# Altium schematic sheet [03] Connectors.SchDoc
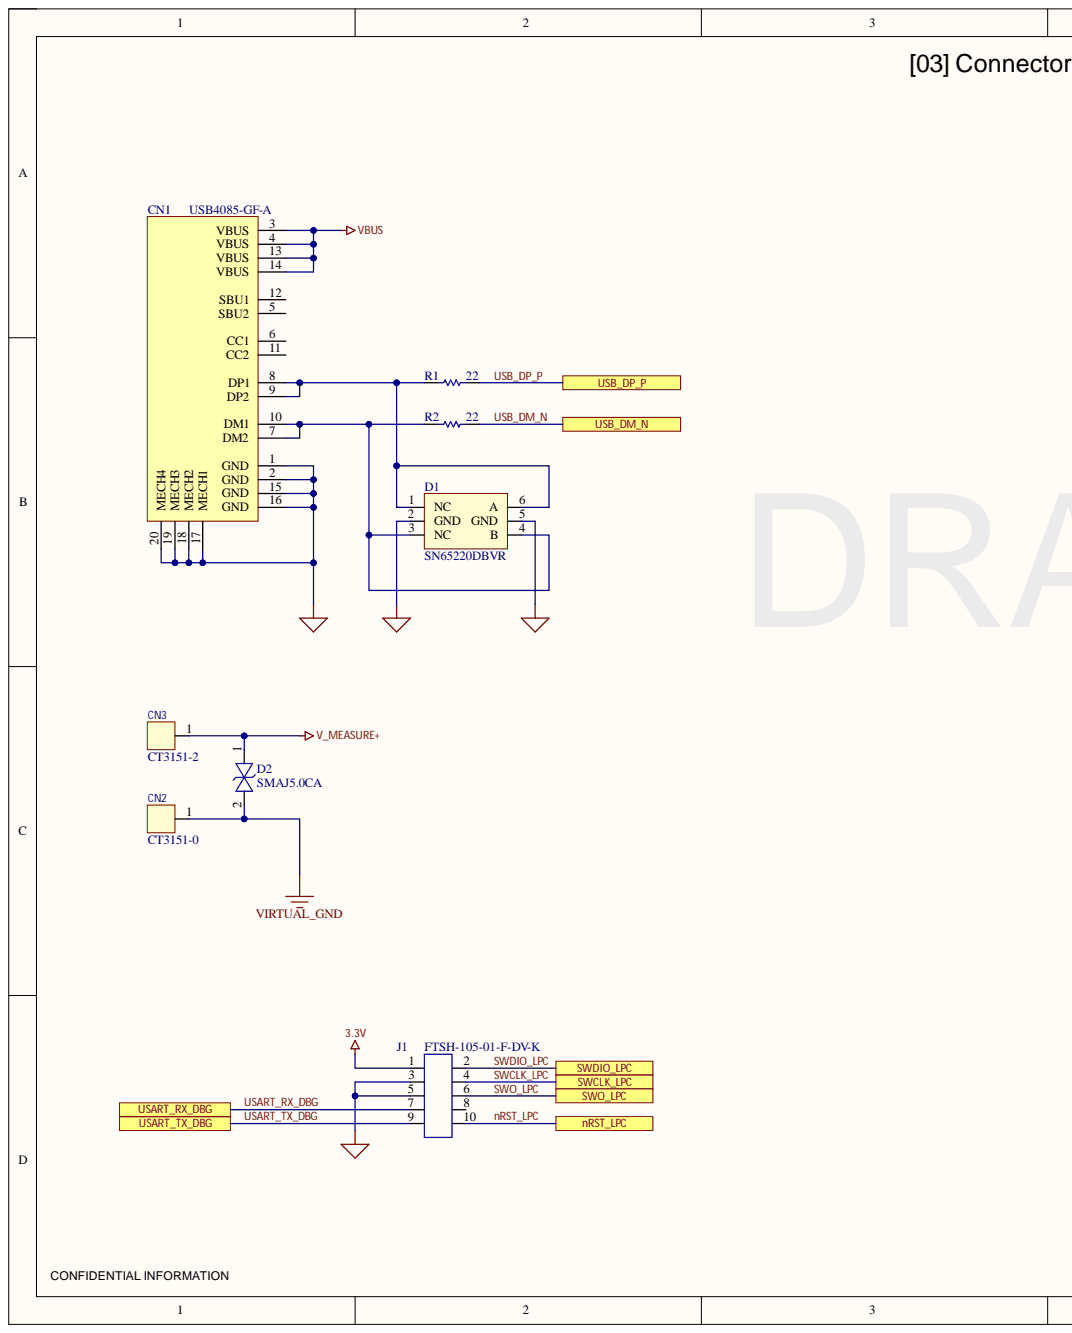
[diagram p0: [03] Connectors.SchDoc, left]
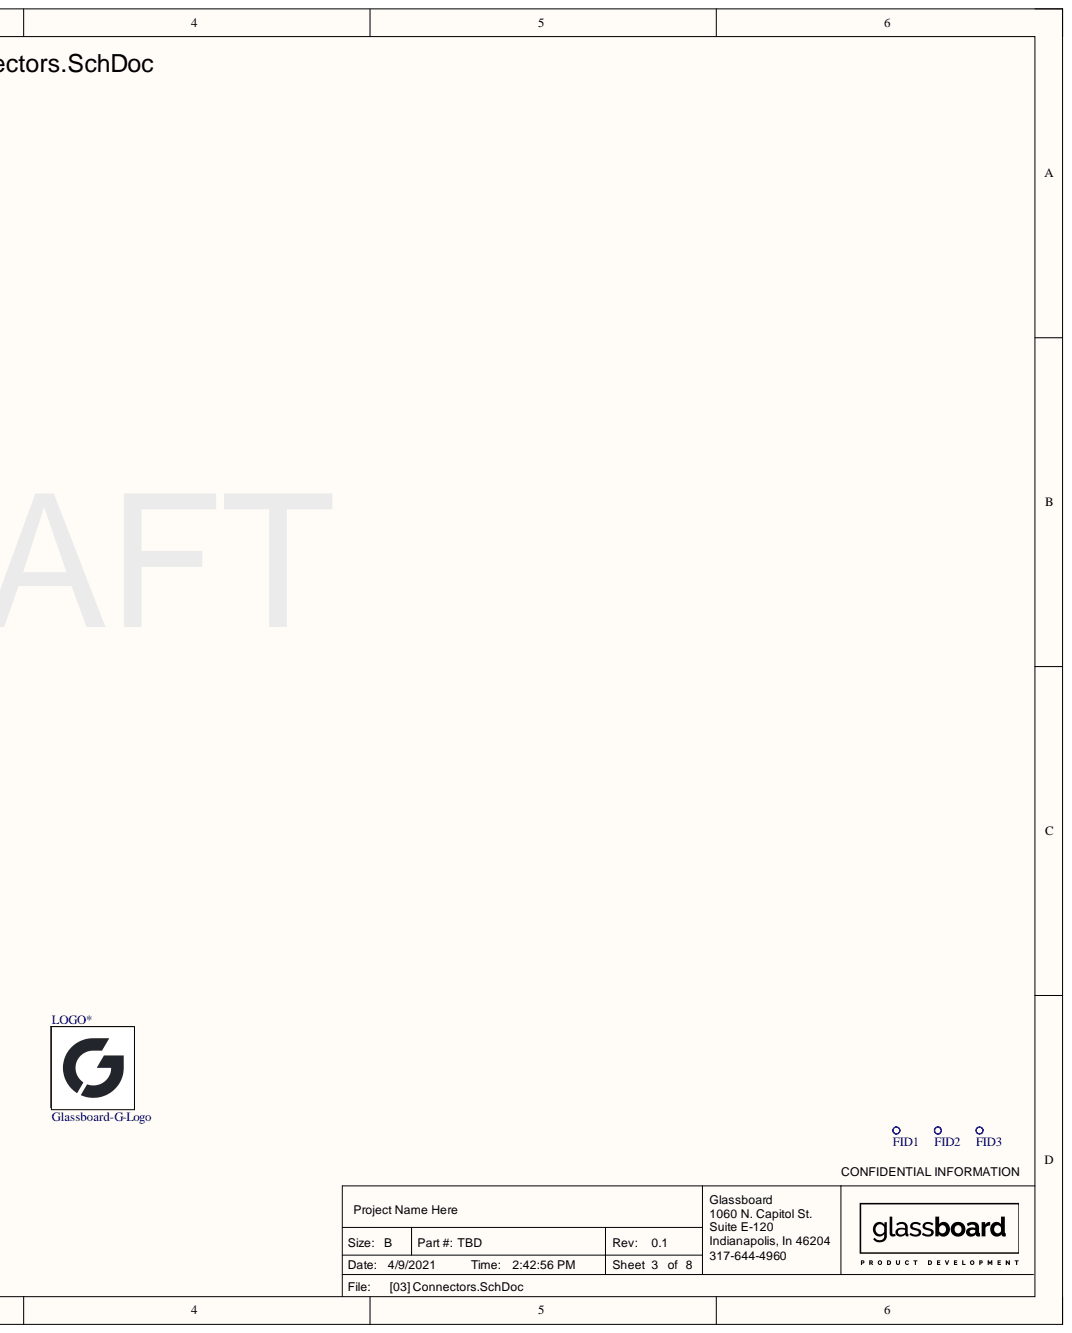
[diagram p1: [03] Connectors.SchDoc, right]

## Parts
CN1  USB4085-GF-A  [USB4085-GF-A]
CN2  CT3151-0  [CT3151-0]
CN3  CT3151-2  [CT3151-2]
D1  SN65220DBVR  [SN65220DBVR-N]
D2  SMAJ5.0CA  [DIOM4326X23L_SMA]
FID1  FID  [fid_30mil]  (no BOM)
FID2  FID  [fid_30mil]  (no BOM)
FID3  FID  [fid_30mil]  (no BOM)
J1  FTSH-105-01-F-DV-K  [FTSH-105-01-F-DV-K]
LOGO*  Glassboard-G-Logo  [GLASSBOARD-G-7X7MM]  (no BOM)
R1  22  [RESC0402(1005)_L]
R2  22  [RESC0402(1005)_L]

## Ports
nRST_LPC, SWCLK_LPC, SWDIO_LPC, SWO_LPC, USART_RX_DBG, USART_TX_DBG, USB_DM_N, USB_DP_P

## Nets
3.3V: J1.1
GND: CN1.1(GND) CN1.2(GND) CN1.15(GND) CN1.16(GND) CN1.17(MECH1) CN1.18(MECH2) CN1.19(MECH3) CN1.20(MECH4) D1.2(GND) D1.5(GND) J1.3 J1.5
V_MEASURE+: CN3.1 D2.1
VBUS: CN1.3(VBUS) CN1.4(VBUS) CN1.13(VBUS) CN1.14(VBUS)
VIRTUAL_GND: CN2.1 D2.2
nRST_LPC: J1.10 ; port nRST_LPC
SWCLK_LPC: J1.4 ; port SWCLK_LPC
SWDIO_LPC: J1.2 ; port SWDIO_LPC
SWO_LPC: J1.6 ; port SWO_LPC
USART_RX_DBG: J1.7 ; port USART_RX_DBG
USART_TX_DBG: J1.9 ; port USART_TX_DBG
USB_DM_N: R2.2 ; port USB_DM_N
USB_DP_P: R1.2 ; port USB_DP_P
NetCN1_7: CN1.7(DM2) CN1.10(DM1) D1.3(NC) D1.4(B) R2.1
NetCN1_8: CN1.8(DP1) CN1.9(DP2) D1.1(NC) D1.6(A) R1.1

Not connected: CN1 5(SBU2) 6(CC1) 11(CC2) 12(SBU1); FID1 0; FID2 0; FID3 0; J1 8

## Library data of the parts
CN1 = USB4085-GF-A: CONN RCPT USB2.0 TYPEC 16POS; Manufacturer: GCT; Database Table Name: Connectors; ESD: NO; Component Kind: Standard; Component Type: CONN - PTH; Current: N/A; Distributor 1: Digi-Key; Distributor 1 Part Number: 2073-USB4085-GF-ACT-ND; Manufacturer Part Number: USB4085-GF-A; Moisture Sensitivity: NO; Package Type: PTH; Part Number: USB4085-GF-A; Pin Count: 20; Published: 12/8/2020; Status: Active; Value: USB4085-GF-A; Voltage: N/A; Library Name: Glassboard Component Database.DbLib; Publisher: Stephen Murphy
CN2 = CT3151-0: CONN BANANA JACK SOLDER BLACK; Manufacturer: Cal Test Electronics; Database Table Name: Connectors; ESD: NO; Component Kind: Standard; Component Type: CONN - PTH; Current: N/A; Distributor 1: Digi-Key; Distributor 1 Part Number: BKCT3151-0-ND; Manufacturer Part Number: CT3151-0; Moisture Sensitivity: NO; Package Type: PTH; Part Number: CT3151-0; Pin Count: 1; Published: 3/4/2021; Status: Active; Value: CT3151-0; Voltage: N/A; Library Name: Glassboard Component Database.DbLib; Publisher: Ethan Harmon
CN3 = CT3151-2: CONN BANANA JACK SOLDER RED; Manufacturer: Cal Test Electronics; Database Table Name: Connectors; ESD: NO; Component Kind: Standard; Component Type: CONN - PTH; Current: N/A; Distributor 1: Digi-Key; Distributor 1 Part Number: BKCT3151-2-ND; Manufacturer Part Number: CT3151-2; Moisture Sensitivity: NO; Package Type: PTH; Part Number: CT3151-2; Pin Count: 1; Published: 3/4/2021; Status: Active; Value: CT3151-2; Voltage: N/A; Library Name: Glassboard Component Database.DbLib; Publisher: Ethan Harmon
D1 = SN65220DBVR: IC SINGLE USB PORT TVS SOT-23-6; Manufacturer: Texas Instruments; Database Table Name: Diodes; ESD: YES; Voltage: 5V; Component Kind: Standard; Component Type: DIO - SMD; Current: N/A; Distributor 1: Digi-Key; Distributor 1 Part Number: 296-9694-1-ND; Manufacturer Part Number: SN65220DBVR; Moisture Sensitivity: YES; Package: SOT-23-6; Package Type: SMD; Part Number: SN65220DBVR; Pin Count: 6; Published: 5/9/2016; Status: Active; Tolerance: N/A; Value: SN65220DBVR; Library Name: Glassboard Component Database.DbLib; Publisher: Randy Parmerlee
D2 = SMAJ5.0CA: TVS DIODE 5VWM 9.2VC SMA; Manufacturer: Littlefuse Inc.; Current: 12.3A; Database Table Name: Diodes; ESD: yes; Voltage: 32.4V; Component Kind: Standard; Component Type: DIO - SMD; Distributor 1: Digi-Key; Distributor 1 Part Number: SMAJ5.0CALFCT-ND; Manufacturer Part Number: SMAJ5.0CA; Moisture Sensitivity: yes; Package: SMA; Package Type: SMD; Part Number: SMAJ5.0CA; Pin Count: 2; Published: 12/23/2015; Status: Active; Tolerance: N/A; Value: SMAJ5.0CA; Library Name: Glassboard Component Database.DbLib; Publisher: Randy Parmerlee
FID1-FID3 = Fiducial: Surface Mount Fiducials; Database Table Name: Connectors; ESD: NO; Component Type: FID - SMD; Current: N/A; Distributor 1: N/A; Distributor 1 Part Number: N/A; Manufacturer: N/A; Manufacturer Part Number: N/A; Moisture Sensitivity: NO; Package Type: N/A; Part Number: Fiducial; Pin Count: 1; Published: 11/19/2015; Status: Active; Value: FID; Voltage: N/A; Library Name: Glassboard Component Database.DbLib; Publisher: Randy Parmerlee
J1 = FTSH-105-01-F-DV-K: CONN HEADER 10POS DUAL .05" SMD; Manufacturer: Samtec Inc; Database Table Name: Connectors; ESD: NO; Component Kind: Standard; Component Type: CONN - SMD; Current: N/A; Distributor 1: Digi-Key; Distributor 1 Part Number: SAM8796-ND; Manufacturer Part Number: FTSH-105-01-F-DV-K; Moisture Sensitivity: NO; Package Type: SMD; Part Number: FTSH-105-01-F-DV-K; Pin Count: 10; Published: 10/4/2016; Status: Active; Value: FTSH-105-01-F-DV-K; Voltage: N/A; Library Name: Glassboard Component Database.DbLib; Publisher: Drew Westrick
LOGO* = Glassboard-G-Logo-7x7MM: Glassboard Company Logo 7x7mm; Database Table Name: Logos; Library Name: Glassboard Component Database.DbLib; Part Number: Glassboard-G-Logo-7x7MM; Published: 12/10/2020; Status: Active; Publisher: Stephen Murphy
R1 R2 = RC0402FR-0722RL: RES SMD 22 OHM 1% 1/16W 0402; Manufacturer: Yageo; Database Table Name: Resistors; ESD: NO; Tolerance: 0.01; Wattage: 0.063W; Component Kind: Standard; Component Type: RES - CHIP; Distributor 1: Digi-Key; Distributor 1 Part Number: 311-22.0LRCT-ND; Manufacturer Part Number: RC0402FR-0722RL; Moisture Sensitivity: NO; Package: RES0402; Package Type: SMD; Part Number: RC0402FR-0722RL; Pin Count: 2; Published: 9/6/2017; Status: Active; Value: 22; Voltage: N/A; Library Name: Glassboard Component Database.DbLib; Publisher: Randy Parmerlee
